# Altium schematic sheet POWER OUT CLK不焊接.SchDoc
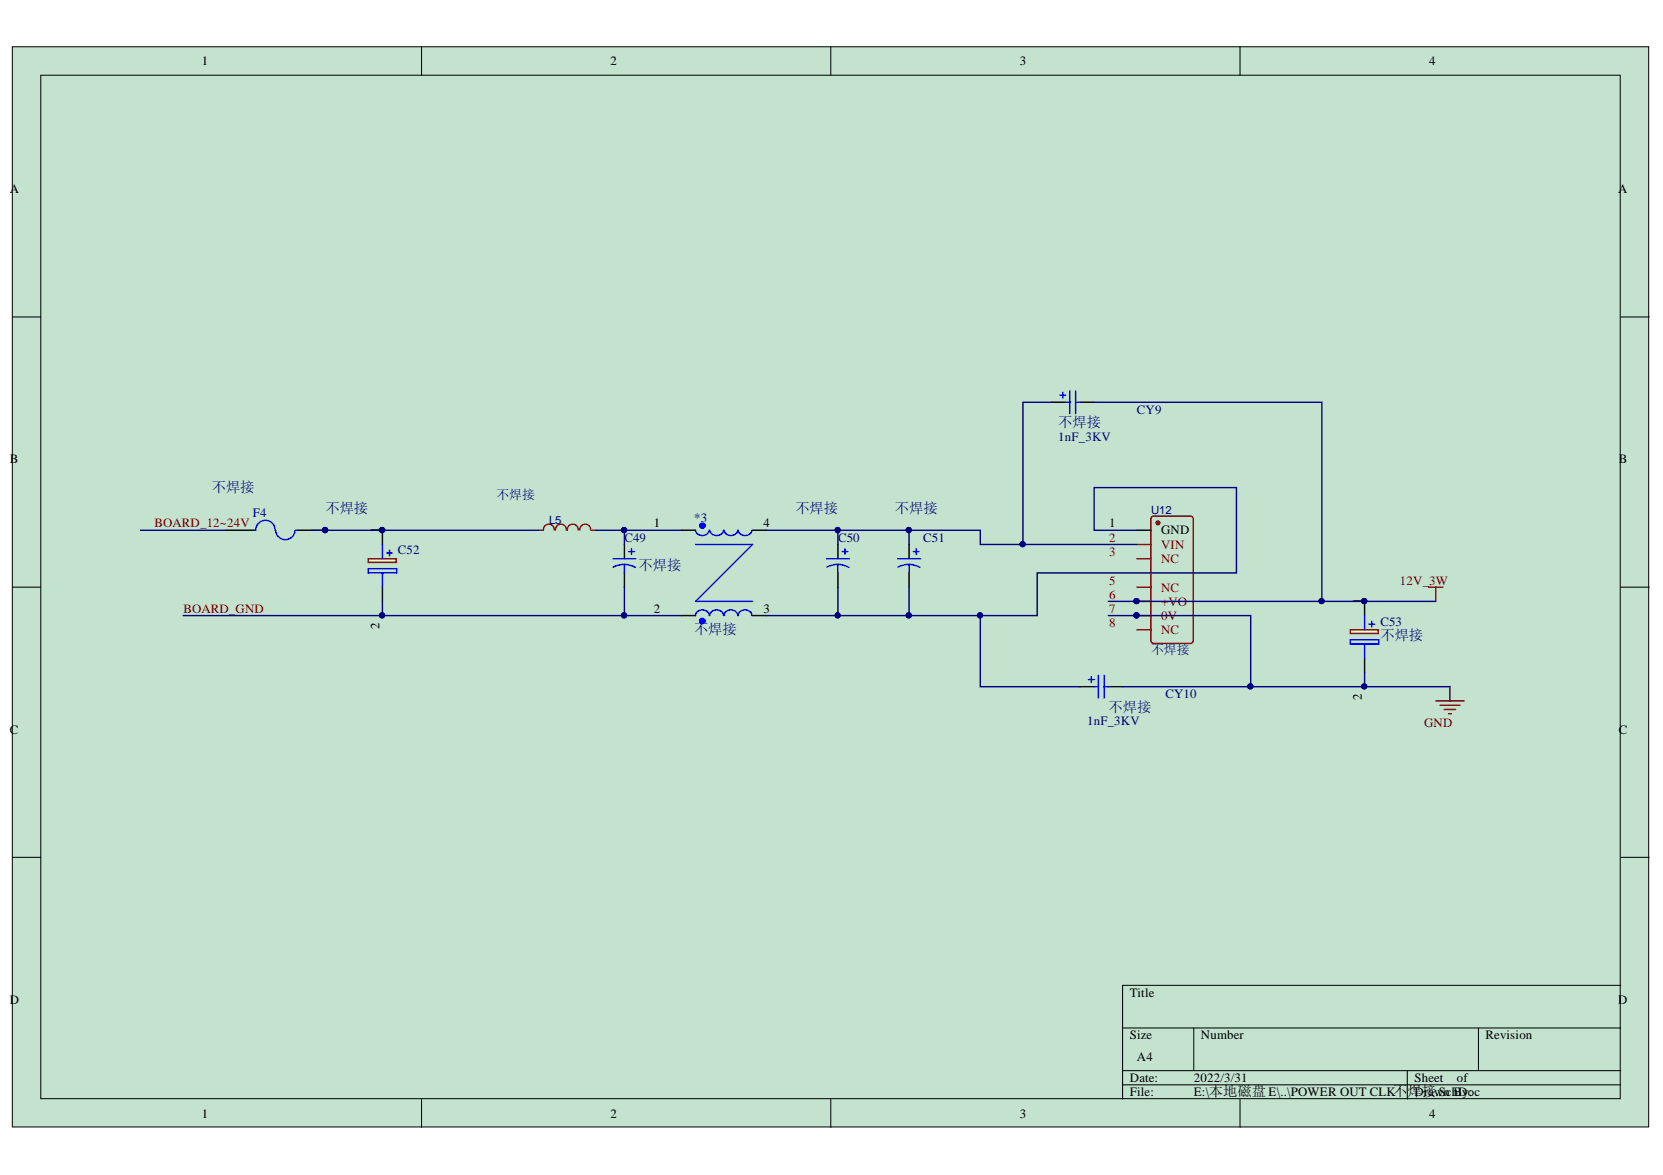
[diagram p0: POWER OUT CLK不焊接.SchDoc]

## Parts
*3  不焊接  [FL2D-XX-XXX]
C49  不焊接  [C1210_N]
C50  不焊接  [C1210_N]
C51  不焊接  [EEE0JA152UAP]
C52  不焊接  [CAP12.5*25]
C53  不焊接  [CAPPRD200W55D500H1200]
CY9  不焊接  value 1nF_3KV  [CAP1808]
CY10  不焊接  value 1nF_3KV  [CAP1808]
F4  不焊接  [1206_FUSE]
L5  不焊接  [IND-SMD_L5.2-W5.2_MS0530-XX]
U12  不焊接  [PWRM-TH_UWFXXXXS-3WR3]

## Nets
12V_3W: C53.1 CY9.2 U12.6(+VO)
GND: C53.2 CY10.2 U12.7(0V)
BOARD_12~24V: F4.1
BOARD_GND: *3.2 C49.2 C52.2
Net*3_1: *3.1 C49.1 L5.2
Net*3_3: *3.3 C50.2 C51.2 CY10.1 U12.1(GND)
Net*3_4: *3.4 C50.1 C51.1 CY9.1 U12.2(VIN)
NetC52_1: C52.1 F4.2 L5.1

Not connected: U12 3(NC) 5(NC) 8(NC)

## Library data of the parts
*3 = FL2D: Component Kind: Standard; Library Name: Schlib1.SchLib
C49-C51 = Cap Pol2: Polarized Capacitor (Axial); Value: 10uF; Component Kind: Standard; Library Name: Miscellaneous Devices.IntLib; Published: 8-Jun-2000; Revision: July-2002: Re-released for DXP Platform.; Signal Integrity: Cap Pol; Simulation: CAP; Publisher: Altium Limited
C52 = ECA-1HHG102: Capacitor Polarised; Manufacturer_Name: Panasonic; Component Kind: Standard; Datasheet Link: https://componentsearchengine.com//ECA-1HHG102.pdf; Height: 27mm; Manufacturer_Part_Number: ECA-1HHG102; Mouser2 Part Number: 667-ECA-1HHG102; Mouser2 Price/Stock: https://www.mouser.com/Search/Refine.aspx?Keyword=667-ECA-1HHG102
C53 = ECA-1HHG220I: Capacitor Polarised; Manufacturer_Name: Panasonic; Component Kind: Standard; Datasheet Link: http://industrial.panasonic.com/cdbs/www-data/pdf/RDF0000/ABA0000C1215.pdf; Height: 12mm; Manufacturer_Part_Number: ECA-1HHG220I
CY9 CY10 = Cap Pol2: Polarized Capacitor (Axial); Component Kind: Standard; LatestRevisionDate: 17-Jul-2002; LatestRevisionNote: Re-released for DXP Platform.; Library Name: Miscellaneous Devices.IntLib; PackageDescription: Polarized Capacitor; 2 Leads; PackageReference: POLAR0.8; Published: 8-Jun-2000; Signal Integrity: Cap Pol; Simulation: CAP; Publisher: Altium Limited
F4 = Fuse 2: Fuse; Component Kind: Standard; LatestRevisionDate: 17-Jul-2002; LatestRevisionNote: Re-released for DXP Platform.; PackageReference: PIN-W2/E2.8; Published: 8-Jun-2000; Simulation: FUSE; Library Name: jinrylib.IntLib; Publisher: Altium Limited
L5 = 4.7uH: BOM_Manufacturer: COILMX; BOM_Manufacturer Part: MS0530-4R7M; Contributor: LCSC; nameAlias: Inductance; BOM_JLCPCB Part Class: Extended Part; BOM_Supplier: LCSC; BOM_Supplier Part: C380165; Component Kind: Standard; Library Name: Schlib1.SchLib; spicePre: L; spiceSymbolName: MS0530-4R7M
U12 = UWF1212S-3WR3: BOM_Manufacturer: MORNSUN(金升阳); Contributor: LCSC; BOM_JLCPCB Part Class: Extended Part; BOM_Manufacturer Part: UWF1212S-3WR3; BOM_Supplier: LCSC; BOM_Supplier Part: C2684865; Component Kind: Standard; Library Name: Schlib1.SchLib; spicePre: U; spiceSymbolName: UWF1212S-3WR3
